# Altium schematic sheet Connectors.SchDoc(Connectors)
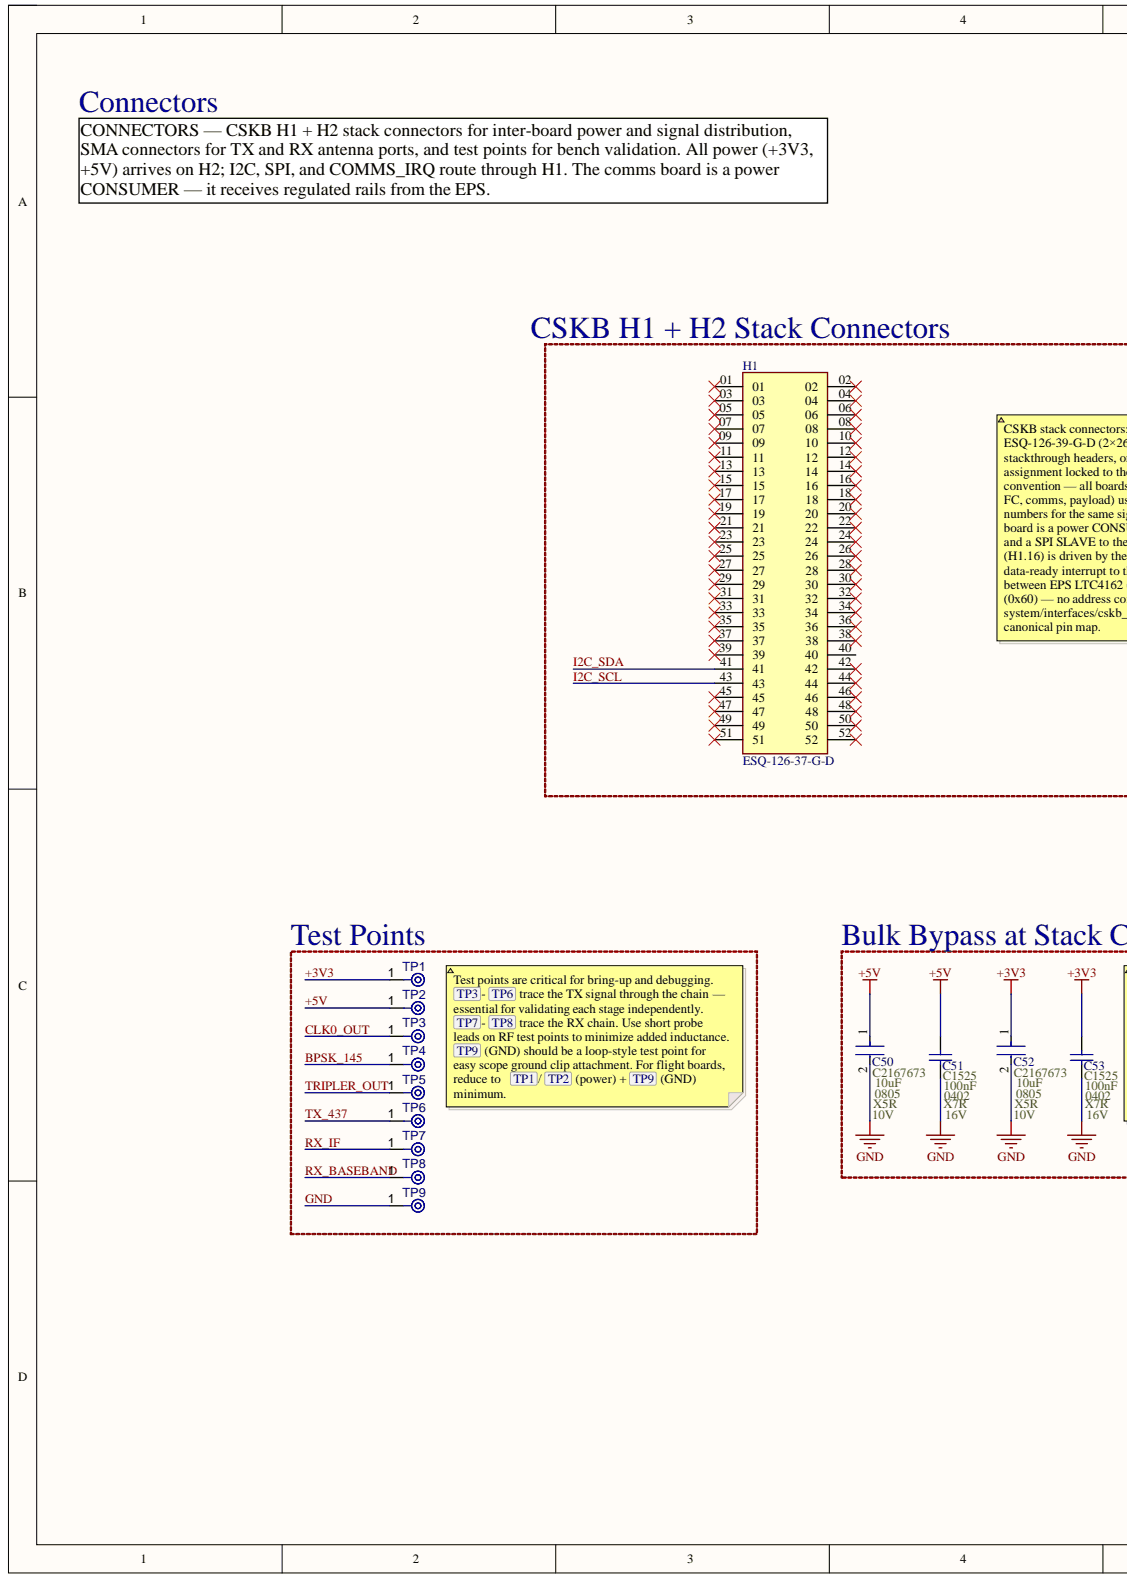
[diagram p0: Connectors.SchDoc, left]
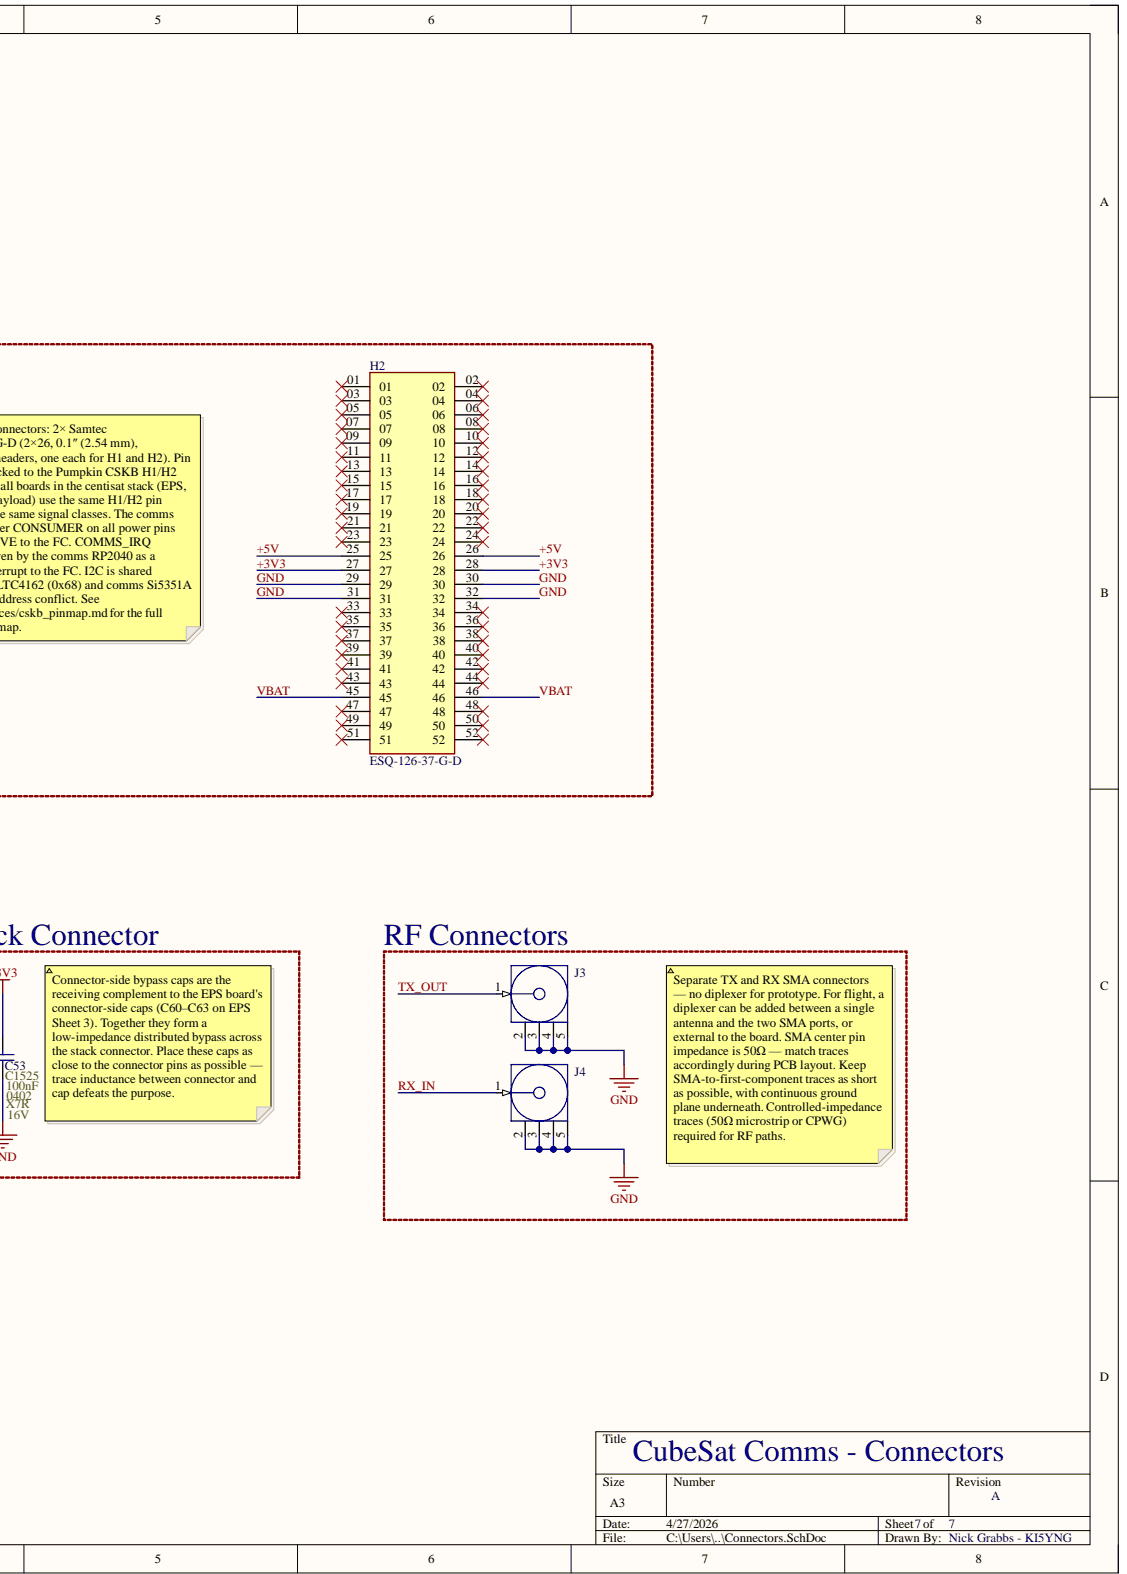
[diagram p1: Connectors.SchDoc, right]

## Parts
C50  C0805C106K8PACTU  [FP-C0805C-DG-MFG]  (value not drawn)
C51  CL05B104KO5NNNC  [CAPC1050X55X23ML05T13]  (value not drawn)
C52  C0805C106K8PACTU  [FP-C0805C-DG-MFG]  (value not drawn)
C53  CL05B104KO5NNNC  [CAPC1050X55X23ML05T13]  (value not drawn)
H1  ESQ-126-37-G-D  [SAMTEC_ESQ-126-37-G-D]
H2  ESQ-126-37-G-D  [SAMTEC_ESQ-126-37-G-D]
J3  60312242114510  [60312242114510]  (value not drawn)
J4  60312242114510  [60312242114510]  (value not drawn)
TP1  TPAD6R  [TP06R]  (value not drawn)  (no BOM)
TP2  TPAD6R  [TP06R]  (value not drawn)  (no BOM)
TP3  TPAD6R  [TP06R]  (value not drawn)  (no BOM)
TP4  TPAD6R  [TP06R]  (value not drawn)  (no BOM)
TP5  TPAD6R  [TP06R]  (value not drawn)  (no BOM)
TP6  TPAD6R  [TP06R]  (value not drawn)  (no BOM)
TP7  TPAD6R  [TP06R]  (value not drawn)  (no BOM)
TP8  TPAD6R  [TP06R]  (value not drawn)  (no BOM)
TP9  TPAD6R  [TP06R]  (value not drawn)  (no BOM)

## Nets
+3V3: C52.1 C53.1 H2.27 H2.28 TP1.1
+5V: C50.1 C51.1 H2.25 H2.26 TP2.1
BPSK_145: TP4.1
CLK0_OUT: TP3.1
GND: C50.2 C51.2 C52.2 C53.2 H2.29 H2.30 H2.31 H2.32 J3.2 J3.3 J3.4 J3.5 J4.2 J4.3 J4.4 J4.5 TP9.1
I2C_SCL: H1.43
I2C_SDA: H1.41
RX_BASEBAND: TP8.1
RX_IF: TP7.1
RX_IN: J4.1
TRIPLER_OUT: TP5.1
TX_437: TP6.1
TX_OUT: J3.1
VBAT: H2.45 H2.46

Not connected: H1 01 02 03 04 05 06 07 08 09 10 11 12 13 14 15 16 17 18 19 20 21 22 23 24 25 26 27 28 29 30 31 32 33 34 35 36 37 38 39 40 42 44 45 46 47 48 49 50 51 52; H2 01 02 03 04 05 06 07 08 09 10 11 12 13 14 15 16 17 18 19 20 21 22 23 24 33 34 35 36 37 38 39 40 41 42 43 44 47 48 49 50 51 52

## Library data of the parts
C50 C52 = CMP-2007-03243-2: CAP CER 10UF 10V X5R 0805; 3DS Part Number: 220-00001; Manufacturer: Kemet; Material: Ceramic; Temperature Coefficient: 15%; Tolerance: 10%; Automotive: No; Capacitance: 10uF; Category: Cap; Component Kind: Standard; ComponentLink2Description: Datasheet; ComponentLink2URL: https://content.kemet.com/datasheets/KEM_C1006_X5R_SMD.pdf; Device Class L1: Passive Components; Device Class L2: Capacitors; Device Class L3: Ceramic Capacitors; Digikey Description: CAP CER 10UF 10V X5R 0805; Height: 1.4mm; Ipc Land Pattern Name: CAPC200125X125; LCSC Part #: C2167673; Lead Free: Yes; Library Name: Altium Content Vault; Manufacturer Part Number: C0805C106K8PACTU; Mouser Description: CAP CER 10UF 10V X5R 0805; Octopart: https://octopart.com/c0805c106k8pactu-kemet-98697; Package: 0805; RoHS: TRUE; Temperature: -55°C to +85°C; Temperature Characteristic: X5R; Temperature Range High: +85°C; Temperature Range Low: -55°C; Value: 10uF; Voltage: 10V; Voltage Rating: 10V
C51 C53 = CMP-2000-05439-1: Chip Capacitor, 100 nF, +/- 10%, 16 V, -55 to 125 degC, 0402 (1005 Metric), RoHS, Tape and Reel; Manufacturer: Samsung Electro-Mechanics; Capacitance: 100nF; Dielectric: X7R; Rated Voltage: 16V; Case Size/Dimension: 0402; Component Kind: Standard; Datasheet URL: https://datasheet.ciiva.com/29198/458718-da-01-en-vs-kondensator-0402-29198423.pdf; Datasheet Version: Nov-10; LCSC Part #: C1525; Library Name: Altium Content Vault; Manufacturer URL: http://www.samsungsem.com/global/index.jsp; Tolerance (%): ±10%
H1 H2 = CMP-015-000000-1: MF: Samtec; Availability: Unavailable; Component Kind: Standard; Component Type: Standard; Design Item ID: ESQ-126-37-G-D; MP: ESQ-126-37-G-D; Package: None; Pin Count: 52; Price: None; Source: ESQ-126-37-G-D.SchLib; Library Name: Nick Grabbs
J3 J4 = CMP-03913-97004434-3: Rf Coax Conn, Sma Jack, 50 Ohm, Pcb Rohs Compliant: Yes; Impedance: 50Ω; Insertion Loss (dB): 0.14dB; Insulation Resistance: 5GΩ; Component Kind: Standard; Connector Type: SMA; Insulation Material: PTFE; Library Name: Altium Content Vault; Max Operating Temperature: 165°C; Min Operating Temperature: -65°C; Orientation: Straight
TP1-TP9 = CMP-00028-00002-2: 0.6mm round; Pins: 1; Library Name: Nick Grabbs
